# Altium schematic sheet TopRow.SchDoc
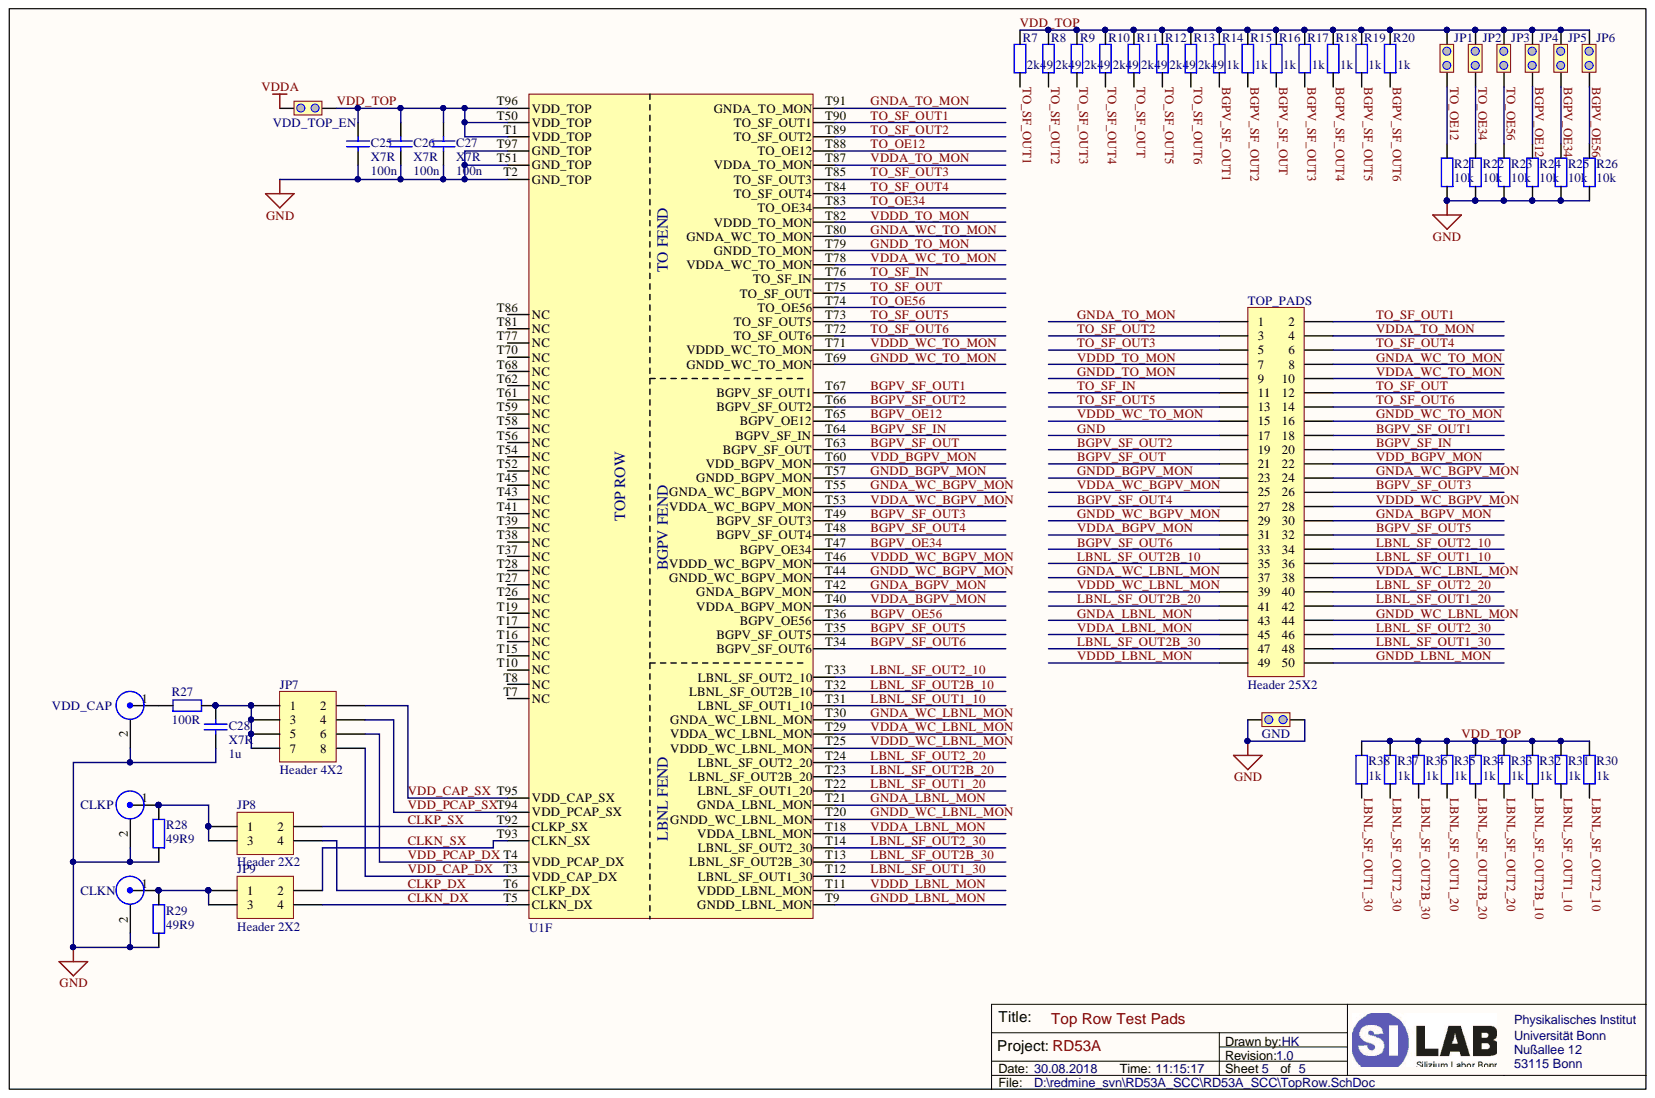
[diagram p0: TopRow.SchDoc]

## Parts
C25  X7R  value 100n  [C0402_imp_L]
C26  X7R  value 100n  [C0402_imp_L]
C27  X7R  value 100n  [C0402_imp_L]
C28  X7R  value 1u  [C0603_imp_L]
CLKN  -  [LEMO horiz.]  (value not drawn)
CLKP  -  [LEMO horiz.]  (value not drawn)
GND  -  [CON 1x2 std]  (value not drawn)
JP1  -  [CON 1x2 std]  (value not drawn)
JP2  -  [CON 1x2 std]  (value not drawn)
JP3  -  [CON 1x2 std]  (value not drawn)
JP4  -  [CON 1x2 std]  (value not drawn)
JP5  -  [CON 1x2 std]  (value not drawn)
JP6  -  [CON 1x2 std]  (value not drawn)
JP7  Header 4X2  [HDR2X4]
JP8  Header 2X2  [HDR2X2]
JP9  Header 2X2  [HDR2X2]
R7  R  value 2k49  [R0402_imp_N]
R8  R  value 2k49  [R0402_imp_N]
R9  R  value 2k49  [R0402_imp_N]
R10  R  value 2k49  [R0402_imp_N]
R11  R  value 2k49  [R0402_imp_N]
R12  R  value 2k49  [R0402_imp_N]
R13  R  value 2k49  [R0402_imp_N]
R14  R  value 1k  [R0402_imp_N]
R15  R  value 1k  [R0402_imp_N]
R16  R  value 1k  [R0402_imp_N]
R17  R  value 1k  [R0402_imp_N]
R18  R  value 1k  [R0402_imp_N]
R19  R  value 1k  [R0402_imp_N]
R20  R  value 1k  [R0402_imp_N]
R21  R  value 10k  [R0402_imp_N]
R22  R  value 10k  [R0402_imp_N]
R23  R  value 10k  [R0402_imp_N]
R24  R  value 10k  [R0402_imp_N]
R25  R  value 10k  [R0402_imp_N]
R26  R  value 10k  [R0402_imp_N]
R27  R  value 100R  [R0402_imp_N]
R28  R  value 49R9  [R0402_imp_N]
R29  R  value 49R9  [R0402_imp_N]
R30  R  value 1k  [R0402_imp_N]
R31  R  value 1k  [R0402_imp_N]
R32  R  value 1k  [R0402_imp_N]
R33  R  value 1k  [R0402_imp_N]
R34  R  value 1k  [R0402_imp_N]
R35  R  value 1k  [R0402_imp_N]
R36  R  value 1k  [R0402_imp_N]
R37  R  value 1k  [R0402_imp_N]
R38  R  value 1k  [R0402_imp_N]
TOP_PADS  Header 25X2  [HDR2X25]
U1  RD53A  [RD53A Chip]  (value not drawn)  (part F)
VDD_CAP  -  [LEMO horiz.]  (value not drawn)
VDD_TOP_EN  -  [CON 1x2 std]  (value not drawn)

## Nets
VDDA: VDD_TOP_EN.1
BGPV_OE12: JP4.1 R24.2 U1.T65(BGPV_OE12)
BGPV_OE34: JP5.1 R25.2 U1.T47(BGPV_OE34)
BGPV_OE56: JP6.1 R26.2 U1.T36(BGPV_OE56)
BGPV_SF_IN: TOP_PADS.20 U1.T64(BGPV_SF_IN)
BGPV_SF_OUT: R16.1 TOP_PADS.21 U1.T63(BGPV_SF_OUT)
BGPV_SF_OUT1: R14.1 TOP_PADS.18 U1.T67(BGPV_SF_OUT1)
BGPV_SF_OUT2: R15.1 TOP_PADS.19 U1.T66(BGPV_SF_OUT2)
BGPV_SF_OUT3: R17.1 TOP_PADS.26 U1.T49(BGPV_SF_OUT3)
BGPV_SF_OUT4: R18.1 TOP_PADS.27 U1.T48(BGPV_SF_OUT4)
BGPV_SF_OUT5: R19.1 TOP_PADS.32 U1.T35(BGPV_SF_OUT5)
BGPV_SF_OUT6: R20.1 TOP_PADS.33 U1.T34(BGPV_SF_OUT6)
CLKN_DX: JP9.4 U1.T5(CLKN_DX)
CLKN_SX: JP9.2 U1.T93(CLKN_SX)
CLKP_DX: JP8.4 U1.T6(CLKP_DX)
CLKP_SX: JP8.2 U1.T92(CLKP_SX)
GND: C25.2 C26.2 C27.2 C28.2 CLKN.2 CLKP.2 GND.1 GND.2 R21.1 R22.1 R23.1 R24.1 R25.1 R26.1 R28.1 R29.1 TOP_PADS.17 U1.T2(GND_TOP) U1.T51(GND_TOP) U1.T97(GND_TOP) VDD_CAP.2
GNDA_BGPV_MON: TOP_PADS.30 U1.T42(GNDA_BGPV_MON)
GNDA_LBNL_MON: TOP_PADS.43 U1.T21(GNDA_LBNL_MON)
GNDA_TO_MON: TOP_PADS.1 U1.T91(GNDA_TO_MON)
GNDA_WC_BGPV_MON: TOP_PADS.24 U1.T55(GNDA_WC_BGPV_MON)
GNDA_WC_LBNL_MON: TOP_PADS.37 U1.T30(GNDA_WC_LBNL_MON)
GNDA_WC_TO_MON: TOP_PADS.8 U1.T80(GNDA_WC_TO_MON)
GNDD_BGPV_MON: TOP_PADS.23 U1.T57(GNDD_BGPV_MON)
GNDD_LBNL_MON: TOP_PADS.50 U1.T9(GNDD_LBNL_MON)
GNDD_TO_MON: TOP_PADS.9 U1.T79(GNDD_TO_MON)
GNDD_WC_BGPV_MON: TOP_PADS.29 U1.T44(GNDD_WC_BGPV_MON)
GNDD_WC_LBNL_MON: TOP_PADS.44 U1.T20(GNDD_WC_LBNL_MON)
GNDD_WC_TO_MON: TOP_PADS.16 U1.T69(GNDD_WC_TO_MON)
LBNL_SF_OUT1_10: R31.2 TOP_PADS.36 U1.T31(LBNL_SF_OUT1_10)
LBNL_SF_OUT1_20: R35.2 TOP_PADS.42 U1.T22(LBNL_SF_OUT1_20)
LBNL_SF_OUT1_30: R38.2 TOP_PADS.48 U1.T12(LBNL_SF_OUT1_30)
LBNL_SF_OUT2_10: R30.2 TOP_PADS.34 U1.T33(LBNL_SF_OUT2_10)
LBNL_SF_OUT2_20: R33.2 TOP_PADS.40 U1.T24(LBNL_SF_OUT2_20)
LBNL_SF_OUT2_30: R37.2 TOP_PADS.46 U1.T14(LBNL_SF_OUT2_30)
LBNL_SF_OUT2B_10: R32.2 TOP_PADS.35 U1.T32(LBNL_SF_OUT2B_10)
LBNL_SF_OUT2B_20: R34.2 TOP_PADS.41 U1.T23(LBNL_SF_OUT2B_20)
LBNL_SF_OUT2B_30: R36.2 TOP_PADS.47 U1.T13(LBNL_SF_OUT2B_30)
TO_OE12: JP1.1 R21.2 U1.T88(TO_OE12)
TO_OE34: JP2.1 R22.2 U1.T83(TO_OE34)
TO_OE56: JP3.1 R23.2 U1.T74(TO_OE56)
TO_SF_IN: TOP_PADS.11 U1.T76(TO_SF_IN)
TO_SF_OUT: R11.1 TOP_PADS.12 U1.T75(TO_SF_OUT)
TO_SF_OUT1: R7.1 TOP_PADS.2 U1.T90(TO_SF_OUT1)
TO_SF_OUT2: R8.1 TOP_PADS.3 U1.T89(TO_SF_OUT2)
TO_SF_OUT3: R9.1 TOP_PADS.5 U1.T85(TO_SF_OUT3)
TO_SF_OUT4: R10.1 TOP_PADS.6 U1.T84(TO_SF_OUT4)
TO_SF_OUT5: R12.1 TOP_PADS.13 U1.T73(TO_SF_OUT5)
TO_SF_OUT6: R13.1 TOP_PADS.14 U1.T72(TO_SF_OUT6)
VDD_BGPV_MON: TOP_PADS.22 U1.T60(VDD_BGPV_MON)
VDD_CAP_DX: JP7.8 U1.T3(VDD_CAP_DX)
VDD_CAP_SX: JP7.2 U1.T95(VDD_CAP_SX)
VDD_PCAP_DX: JP7.6 U1.T4(VDD_PCAP_DX)
VDD_PCAP_SX: JP7.4 U1.T94(VDD_PCAP_SX)
VDD_TOP: C25.1 C26.1 C27.1 JP1.2 JP2.2 JP3.2 JP4.2 JP5.2 JP6.2 R7.2 R8.2 R9.2 R10.2 R11.2 R12.2 R13.2 R14.2 R15.2 R16.2 R17.2 R18.2 R19.2 R20.2 R30.1 R31.1 R32.1 R33.1 R34.1 R35.1 R36.1 R37.1 R38.1 U1.T1(VDD_TOP) U1.T50(VDD_TOP) U1.T96(VDD_TOP) VDD_TOP_EN.2
VDDA_BGPV_MON: TOP_PADS.31 U1.T40(VDDA_BGPV_MON)
VDDA_LBNL_MON: TOP_PADS.45 U1.T18(VDDA_LBNL_MON)
VDDA_TO_MON: TOP_PADS.4 U1.T87(VDDA_TO_MON)
VDDA_WC_BGPV_MON: TOP_PADS.25 U1.T53(VDDA_WC_BGPV_MON)
VDDA_WC_LBNL_MON: TOP_PADS.38 U1.T29(VDDA_WC_LBNL_MON)
VDDA_WC_TO_MON: TOP_PADS.10 U1.T78(VDDA_WC_TO_MON)
VDDD_LBNL_MON: TOP_PADS.49 U1.T11(VDDD_LBNL_MON)
VDDD_TO_MON: TOP_PADS.7 U1.T82(VDDD_TO_MON)
VDDD_WC_BGPV_MON: TOP_PADS.28 U1.T46(VDDD_WC_BGPV_MON)
VDDD_WC_LBNL_MON: TOP_PADS.39 U1.T25(VDDD_WC_LBNL_MON)
VDDD_WC_TO_MON: TOP_PADS.15 U1.T71(VDDD_WC_TO_MON)
NetC28_1: C28.1 JP7.1 JP7.3 JP7.5 JP7.7 R27.2
NetCLKN_1: CLKN.1 JP9.1 JP9.3 R29.2
NetCLKP_1: CLKP.1 JP8.1 JP8.3 R28.2
NetR27_1: R27.1 VDD_CAP.1

Not connected: U1 T7(NC) T8(NC) T10(NC) T15(NC) T16(NC) T17(NC) T19(NC) T26(NC) T27(NC) T28(NC) T37(NC) T38(NC) T39(NC) T41(NC) T43(NC) T45(NC) T52(NC) T54(NC) T56(NC) T58(NC) T59(NC) T61(NC) T62(NC) T68(NC) T70(NC) T77(NC) T81(NC) T86(NC)

## Library data of the parts
C25-C28 = C: Capacitor; Class: Passive; Constraints: 6.3V; Type_1: SMD Chip Capacitor; Comment_1: -; Component Kind: Standard; HelpURL: -; LatestRevisionDate: 27-Nov-2007; PackageDescription: -; Signal Integrity: Capacitor; Simulation: Capacitor; Library Name: Silab_Lib.IntLib; Publisher: Silab J.S.
CLKN CLKP VDD_CAP = LEMO coax: LEMO/BNC Buchse, aufrecht od. gewinkelt; Class: Connector; Comment_1: LEMO; Type_1: EPL.00.250.NTN; Component Kind: Standard; Constraints: -; HelpURL: -; LatestRevisionDate: 24-Sep-2008; PackageDescription: -; Value: -; Library Name: Silab_Lib.IntLib; Publisher: Silab C.H.
GND JP1-JP6 VDD_TOP_EN = JUMPER 1X2S: Jumper, Solderbridge 1x2; Class: Connector; Comment_1: -; Component Kind: Standard; Constraints: -; HelpURL: -; LatestRevisionDate: 24-Sep-2008; PackageDescription: -; Type_1: -; Value: -; Library Name: Silab_Lib.IntLib; Publisher: Silab C.H.
JP7 = Header 4X2: Header, 4-Pin, Dual row; Component Kind: Standard; LatestRevisionDate: 17-Jul-2002; LatestRevisionNote: Re-released for DXP Platform.; Library Name: Miscellaneous Connectors.IntLib; Signal Integrity: Connector; Publisher: Altium Limited
JP8 JP9 = Header 2X2: Header, 2-Pin, Dual row; Component Kind: Standard; LatestRevisionDate: 17-Jul-2002; LatestRevisionNote: Re-released for DXP Platform.; Library Name: Miscellaneous Connectors.IntLib; Signal Integrity: Connector; Publisher: Altium Limited
R7-R38 = R: SMD Chip Resistor; Class: Passive; Constraints: 1%; Comment_1: -; Component Kind: Standard; HelpURL: -; LatestRevisionDate: 27-Nov-2007; PackageDescription: -; Signal Integrity: Resistor; Simulation: Resistor; Type_1: SMD Chip Resistor; Library Name: Silab_Lib.IntLib; Publisher: Silab J.S.
TOP_PADS = Header 25X2: Header, 25-Pin, Dual row; Component Kind: Standard; LatestRevisionDate: 17-Jul-2002; LatestRevisionNote: Re-released for DXP Platform.; Library Name: Miscellaneous Connectors.IntLib; Signal Integrity: Connector; Publisher: Altium Limited
U1 = RD53A: Component Kind: Standard; Description: RD53A; Library Name: RD53A.SchLib
